# Altium schematic sheet touch.SchDoc(touch)
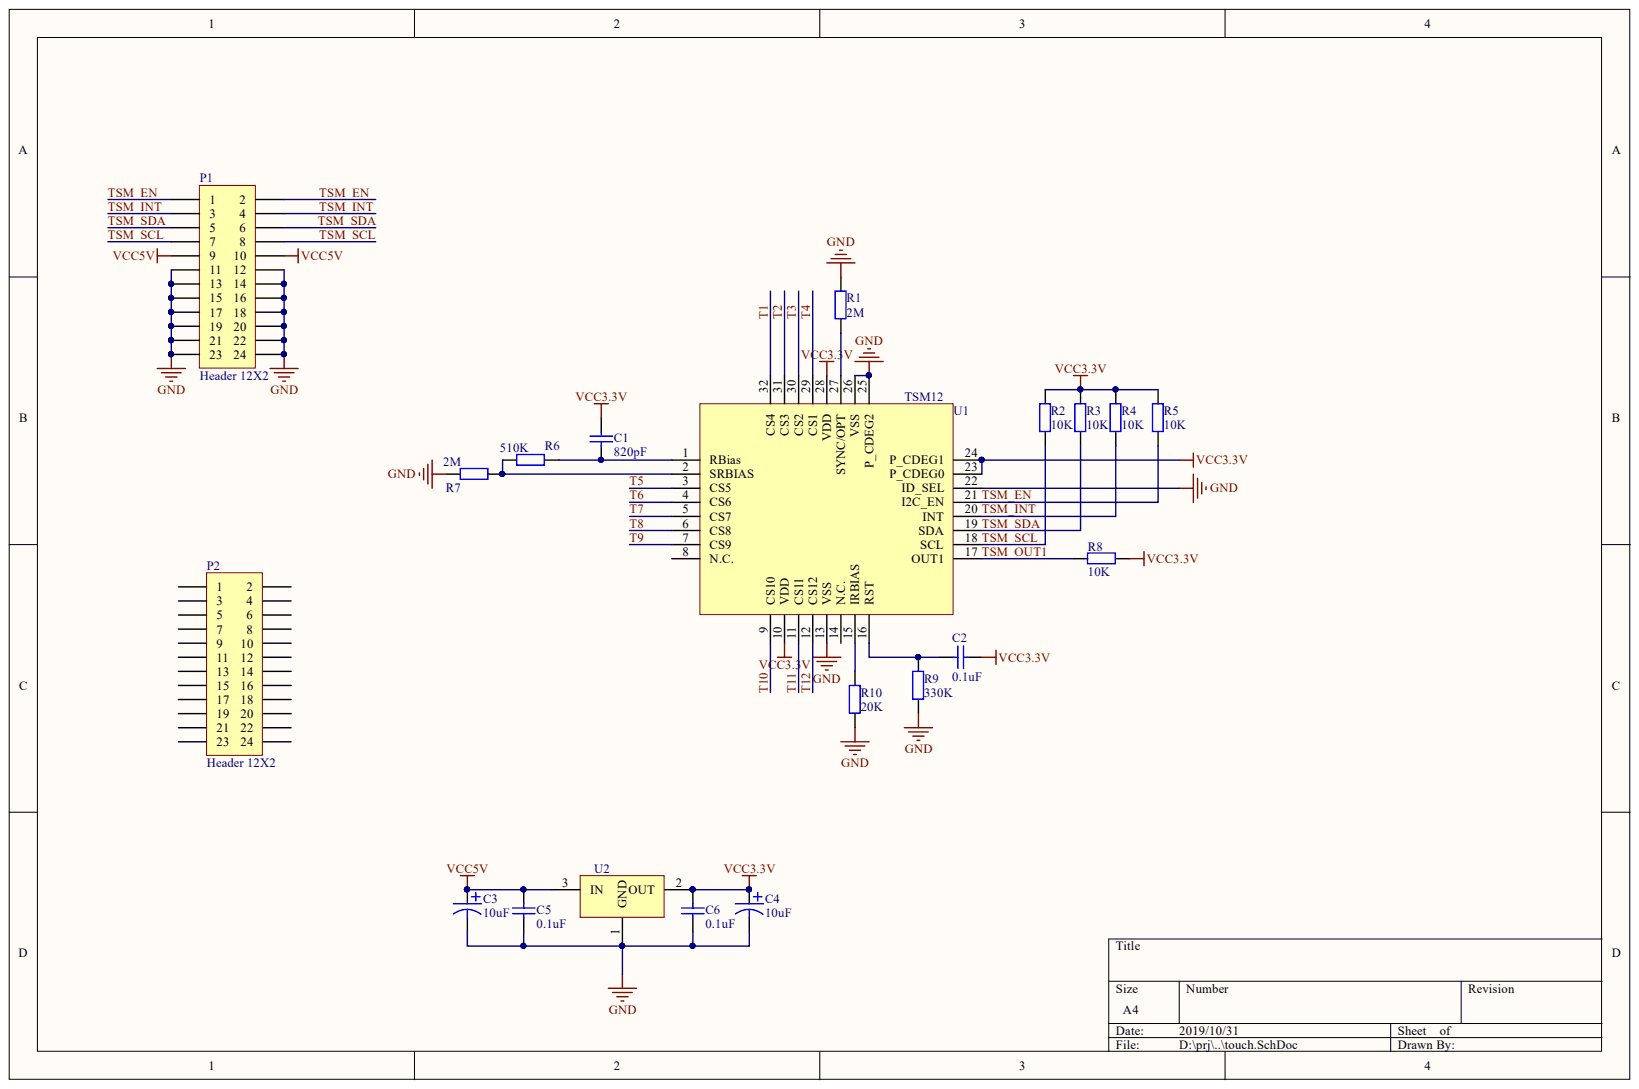
[diagram p0: touch.SchDoc]

## Parts
C1  820pF  [0603_C]
C2  0.1uF  [0603_C]
C3  10uF  [1206_C]
C4  10uF  [1206_C]
C5  0.1uF  [0603_C]
C6  0.1uF  [0603_C]
P1  Header 12X2  [12x2_2.0mm]
P2  Header 12X2  [12x2_2.0mm]
R1  2M  [0603_R]
R2  10K  [0603_R]
R3  10K  [0603_R]
R4  10K  [0603_R]
R5  10K  [0603_R]
R6  510K  [0603_R]
R7  2M  [0603_R]
R8  10K  [0603_R]
R9  330K  [0603_R]
R10  20K  [0603_R]
U1  TSM12  [MLF-32]
U2  LM1117  [SOT-223]  (value not drawn)

## Nets
GND: C3.2 C4.2 C5.2 C6.2 P1.11 P1.12 P1.13 P1.14 P1.15 P1.16 P1.17 P1.18 P1.19 P1.20 P1.21 P1.22 P1.23 P1.24 R1.2 R7.1 R9.1 R10.1 U1.13(VSS) U1.22(ID_SEL) U1.25(P_CDEG2) U1.26(VSS) U2.1
VCC3.3V: C1.2 C2.1 C4.1 C6.1 R2.1 R3.1 R4.1 R5.1 R8.1 U1.10(VDD) U1.23(P_CDEG0) U1.24(P_CDEG1) U1.28(VDD) U2.2
VCC5V: C3.1 C5.1 P1.9 P1.10 U2.3
T1: U1.32(CS4)
T2: U1.31(CS3)
T3: U1.30(CS2)
T4: U1.29(CS1)
T5: U1.3(CS5)
T6: U1.4(CS6)
T7: U1.5(CS7)
T8: U1.6(CS8)
T9: U1.7(CS9)
T10: U1.9(CS10)
T11: U1.11(CS11)
T12: U1.12(CS12)
TSM_EN: P1.1 P1.2 R5.2 U1.21(I2C_EN)
TSM_INT: P1.3 P1.4 R4.2 U1.20(INT)
TSM_OUT1: R8.2 U1.17(OUT1)
TSM_SCL: P1.7 P1.8 R2.2 U1.18(SCL)
TSM_SDA: P1.5 P1.6 R3.2 U1.19(SDA)
NetC1_1: C1.1 R6.2 U1.1(RBias)
NetC2_2: C2.2 R9.2 U1.16(RST)
NetR1_1: R1.1 U1.27(SYNC/OPT)
NetR6_1: R6.1 R7.2 U1.2(SRBIAS)
NetR10_2: R10.2 U1.15(IRBIAS)

Not connected: P2 1 2 3 4 5 6 7 8 9 10 11 12 13 14 15 16 17 18 19 20 21 22 23 24; U1 8(N.C.) 14(N.C.)

## Library data of the parts
C1 C2 C5 C6 = Cap: 贴片电容，0603; Component Kind: Standard; Library Name: stm8l052r8t6开发板.SchLib
C3 C4 = CAPACITOR: 贴片电容，1206; Component Kind: Standard; Library Name: MES-terminal.SchLib
P1 P2 = Header 12X2: Header, 12-Pin, Dual row, 2.0mm; Component Kind: Standard; Library Name: Miscellaneous Connectors.IntLib
R1-R10 = Res2: 贴片电阻，0603; Component Kind: Standard; Library Name: stm8l052r8t6开发板.SchLib
U1 = ADSTSM12: 触摸芯片，TSM12M，MLF-32封装; Component Kind: Standard; Library Name: stm8l052r8t6开发板.SchLib
U2 = LM1117: Component Kind: Standard; Description: LM1117; Library Name: stm8l052r8t6开发板.SchLib
